# Altium schematic sheet WirelessEarBuds_Power.SchDoc
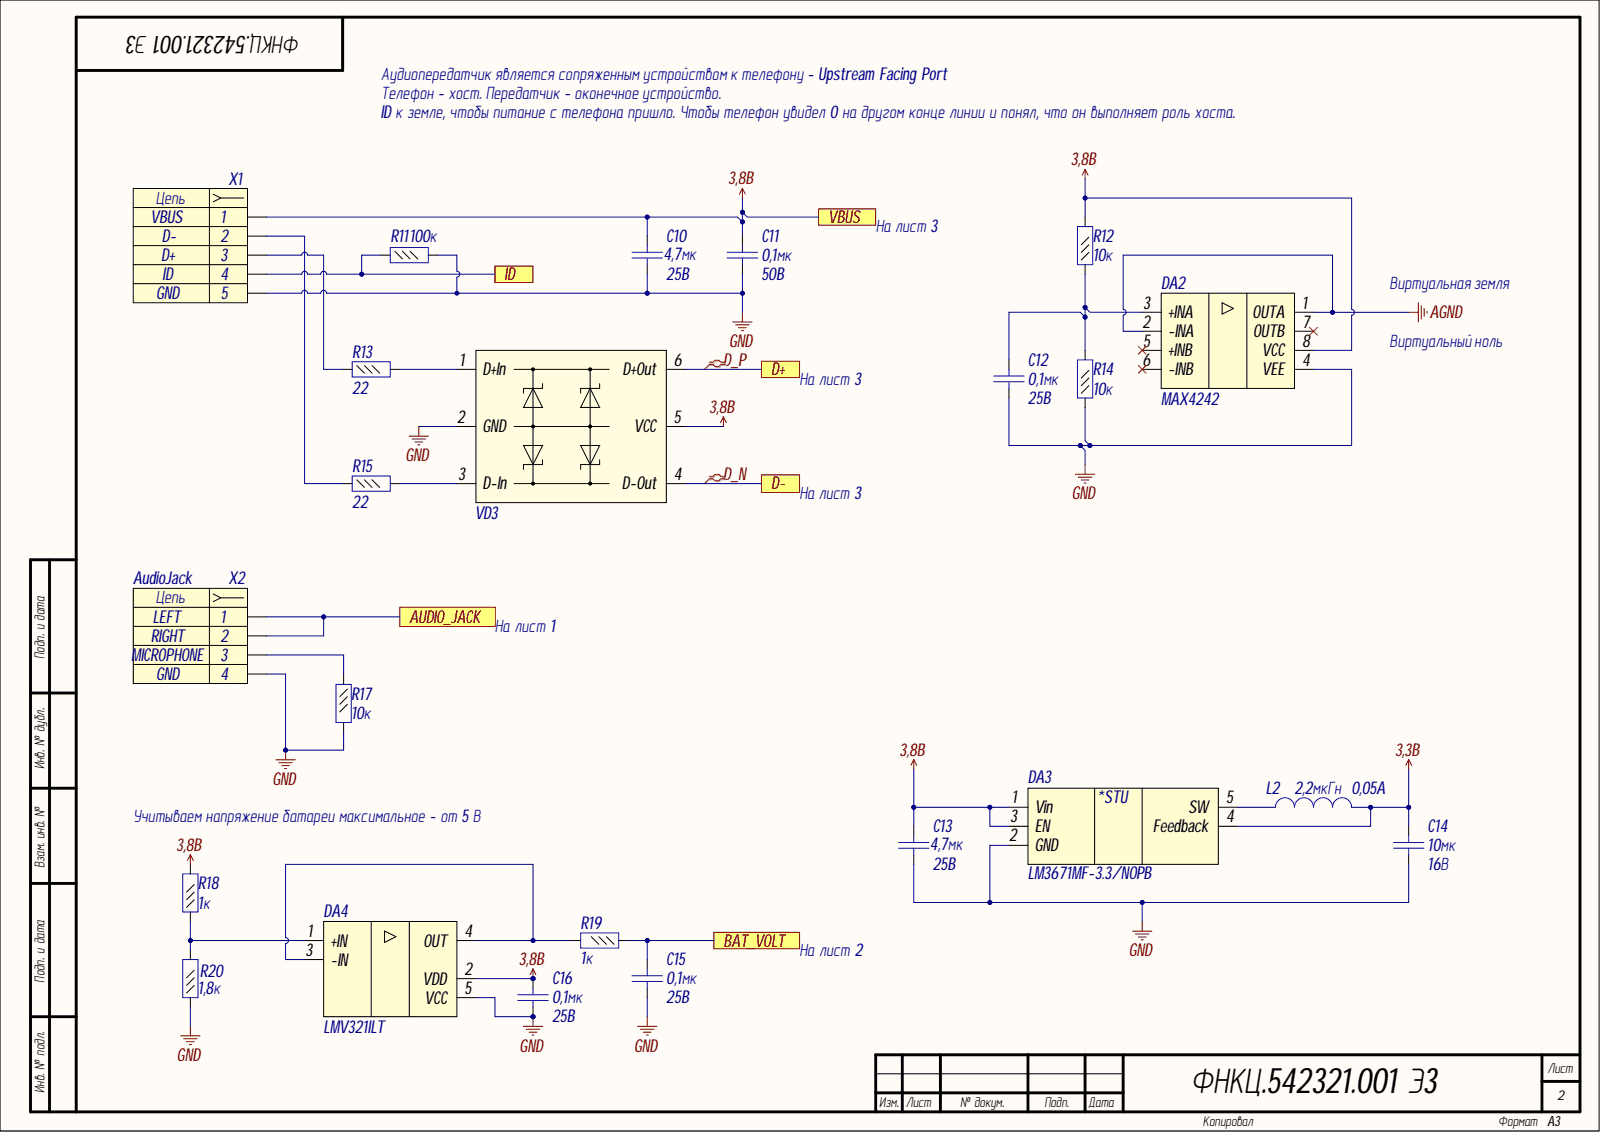
[diagram p0: WirelessEarBuds_Power.SchDoc]

## Parts
C10  value 4,7мк  Voltage: 25B  [C0805]  (hidden comment: Capacitor)
C11  value 0,1мк  Voltage: 50B  [C0603]  (hidden comment: Capacitor)
C12  value 0,1мк  Voltage: 25B  [C0402]  (hidden comment: Capacitor)
C13  value 4,7мк  Voltage: 25B  [C0805]  (hidden comment: Capacitor)
C14  value 10мк  Voltage: 16В  [C1206]  (hidden comment: Capacitor)
C15  value 0,1мк  Voltage: 25B  [C0402]  (hidden comment: Capacitor)
C16  value 0,1мк  Voltage: 25B  [C0402]  (hidden comment: Capacitor)
DA2  MAX4242  [8µMAX]
DA3  LM3671MF-3.3/NOPB  [SOT23-5]
DA4  LMV321ILT  [SOT23-5]
L2  value 2,2мкГн  Rated Current: 0,05A  [L0805]  (hidden comment: Inductor)
R11  value 100к  [R0402]  (hidden comment: Resistor_0,062Вт)
R12  value 10к  [R0402]  (hidden comment: Resistor_0,062Вт)
R13  value 22  [R0402]  (hidden comment: Resistor_0,062Вт)
R14  value 10к  [R0402]  (hidden comment: Resistor_0,062Вт)
R15  value 22  [R0402]  (hidden comment: Resistor_0,062Вт)
R17  value 10к  [R0402]  (hidden comment: Resistor_0,062Вт)
R18  value 1к  [R0402]  (hidden comment: Resistor_0,062Вт)
R19  value 1к  [R0402]  (hidden comment: Resistor_0,062Вт)
R20  value 1,8к  [R0402]  (hidden comment: Resistor_0,062Вт)
VD3  USBUF  [SOT323-6L]  (value not drawn)
X1  Contact_1: VBUS  Contact_2: D-  Contact_3: D+  Contact_4: ID  Contact_5: GND  [5HOLE_SMALL]  (hidden comment: Header_5_sct)
X2  AudioJack  Contact_1: LEFT  Contact_2: RIGHT  Contact_3: MICROPHONE  Contact_4: GND  [4HOLE_SMALL]

## Ports
AUDIO_JACK, BAT_VOLT, D+, D-, ID, VBUS

## Nets
3,3B: C14.2 DA3.4(Feedback) L2.2
AGND: DA2.1(OUTA) DA2.2(-INA)
GND: C10.1 C11.1 C12.2 C13.1 C14.1 C15.2 C16.2 DA2.4(VEE) DA3.2(GND) DA4.5(VCC) R11.2 R14.1 R17.2 R20.1 VD3.2(GND) X1.5 X2.4
3,8B: C10.2 C11.2 C13.2 C16.1 DA2.8(VCC) DA3.1(Vin) DA3.3(EN) DA4.2(VDD) R12.2 R18.2 VD3.5(VCC) X1.1 ; port VBUS
AUDIO_JACK: X2.1 X2.2 ; port AUDIO_JACK
BAT_VOLT: C15.1 R19.1 ; port BAT_VOLT
D_N: VD3.4(D-Out)
D_P: VD3.6(D+Out)
ID: R11.1 X1.4 ; port ID
NetC12_1: C12.1 DA2.3(+INA) R12.1 R14.2
NetDA3_5: DA3.5(SW) L2.1
NetDA4_1: DA4.1(+IN) R18.1 R20.2
NetDA4_3: DA4.3(-IN) DA4.4(OUT) R19.2
NetR13_1: R13.1 X1.3
NetR13_2: R13.2 VD3.1(D+In)
NetR15_1: R15.1 X1.2
NetR15_2: R15.2 VD3.3(D-In)
NetR17_1: R17.1 X2.3

Not connected: DA2 5(+INB) 6(-INB) 7(OUTB)

## Library data of the parts
C10 C13 = cap154: Конденсатор; Manufacture: Murata; Part Name: Ceramic; Part Number: GRM21BR61E475KA12L; Database Table Name: Capacitors$; TKE: X5R; Component Kind: Standard; id: cap154; Library Name: MOP44.DbLib
C11 = cap131: Конденсатор; Manufacture: Murata; Part Name: Ceramic; Part Number: GRM188R71H104K; Database Table Name: Capacitors$; TKE: X7R; Component Kind: Standard; id: cap131; Library Name: MOP44.DbLib
C12 C15 C16 = cap52: Конденсатор; Manufacture: Murata; Part Name: Ceramic; Part Number: GRM155F51E104Z**; Database Table Name: Capacitors$; TKE: Y5V; Component Kind: Standard; id: cap52; Library Name: MOP44.DbLib
C14 = cap191: Конденсатор; Manufacture: Murata; Part Name: Ceramic; Part Number: GRM31CR71C106K*; Database Table Name: Capacitors$; TKE: X7R; Component Kind: Standard; id: cap191; Library Name: MOP44.DbLib
DA2 = amp13: МИКРОМОЩНЫЙ Single/Dual/Quad, +1.8V/10µA, SOT23,  Beyond-the-Rails Op Amps; Manufacture: Maxim Integrated; Part Name: Micropower Operational Amplifiers; Part Number: MAX4242EUA; Bandwidth: 90kHz; Channels: 1; CMRR: 90dB; Database Table Name: Amplifier$; Input Bias Current: (+-)2,3nA; Offset voltage: (+-)0,2mB; PSRR: 85dB; Rail-to-rail: yes; Supply Current max: 10uA; Supply Voltage: 1,8В–5,5В or (+-)0,9В-(+-)2,75B; Component Kind: Standard; id: amp13; Library Name: MOP44.DbLib
DA3 = pwr2: Step-Down DC-DC Converter; Manufacturer: Texas Instruments; Part Name: 600-mA Step-Down DC-DC Converter; Current: 0,6A; Database Table Name: PowerTransducer$; Input Voltage: 2,7 - 5,5B; Output Voltage: 3,3B; Component Kind: Standard; id: pwr2; Library Name: MOP44.DbLib; Part Number: LM3671MF-3.3/NOPB; Type: Impulse
DA4 = amp10: HIGH-DRIVE OPERATIONAL AMPLIFIERS WITH SHUTDOWN; Manufacture: ST Microelectronics; Part Name: Micropower Operational Amplifiers; Bandwidth: 1MHz; Channels: 1; CMRR: 85dB; Database Table Name: Amplifier$; Input Bias Current: 10nA; Offset voltage: 0,1mB; PSRR: 80dB; Rail-to-rail: yes; Supply Current max: 200uA; Supply Voltage: 2,7-6B; Component Kind: Standard; id: amp10; Library Name: MOP44.DbLib; Part Number: LMV321ILT
L2 = ind4: EMI Suppression Filter; Manufacture: Mouser; Part Number: MLF2012A2R2KT000; Database Table Name: Inductor$; Resistance: 500mOhm; Component Kind: Standard; id: ind4; Library Name: MOP44.DbLib; Part Name: Inductor
R11 = res314: Резистор; Manufacture: Yageo; Part Number: RC0402FR-07100KL; Accuracy: 1%; Database Table Name: Resistor$; Component Kind: Standard; id: res314; Library Name: MOP44.DbLib; Part Name: Resistor; Power: 0,062Вт
R12 R14 R17 = res290: Резистор; Manufacture: Yageo; Part Number: RC0402FR-0710KL; Accuracy: 1%; Database Table Name: Resistor$; Component Kind: Standard; id: res290; Library Name: MOP44.DbLib; Part Name: Resistor; Power: 0,062Вт
R13 R15 = res226: Резистор; Manufacture: Yageo; Part Number: RC0402FR-0722RL; Accuracy: 1%; Database Table Name: Resistor$; Component Kind: Standard; id: res226; Library Name: MOP44.DbLib; Part Name: Resistor; Power: 0,062Вт
R18 R19 = res266: Резистор; Manufacture: Yageo; Part Number: RC0402FR-071KL; Accuracy: 1%; Database Table Name: Resistor$; Component Kind: Standard; id: res266; Library Name: MOP44.DbLib; Part Name: Resistor; Power: 0,062Вт
R20 = res272: Резистор; Manufacture: Yageo; Part Number: RC0402FR-071K8; Accuracy: 1%; Database Table Name: Resistor$; Component Kind: Standard; id: res272; Library Name: MOP44.DbLib; Part Name: Resistor; Power: 0,062Вт
VD3 = diode14: EMEMI filter and line termination for USB upstream ports; Manufacture: ST Microelectronics; Part Name: EMI filter for USB upstream ports; Part Number: USBUF02W6; Capacitance: 47pF; Database Table Name: Diode$; Number of Channels: 2; Component Kind: Standard; id: diode14; Library Name: MOP44.DbLib
X1 = connect44: Разъем - 5 конт. розетка; Part Name: SMALL HALL 5; Database Table Name: Connector$; Etch: 1,5mm; Component Kind: Standard; id: connect44; Library Name: MOP44.DbLib
X2 = connect45: Разъем - 4 конт. розетка; Part Name: SMALL HALL 4; Database Table Name: Connector$; Etch: 1,5mm; Component Kind: Standard; id: connect45; Library Name: MOP44.DbLib
